# Altium schematic sheet MCU.SchDoc(MCU)
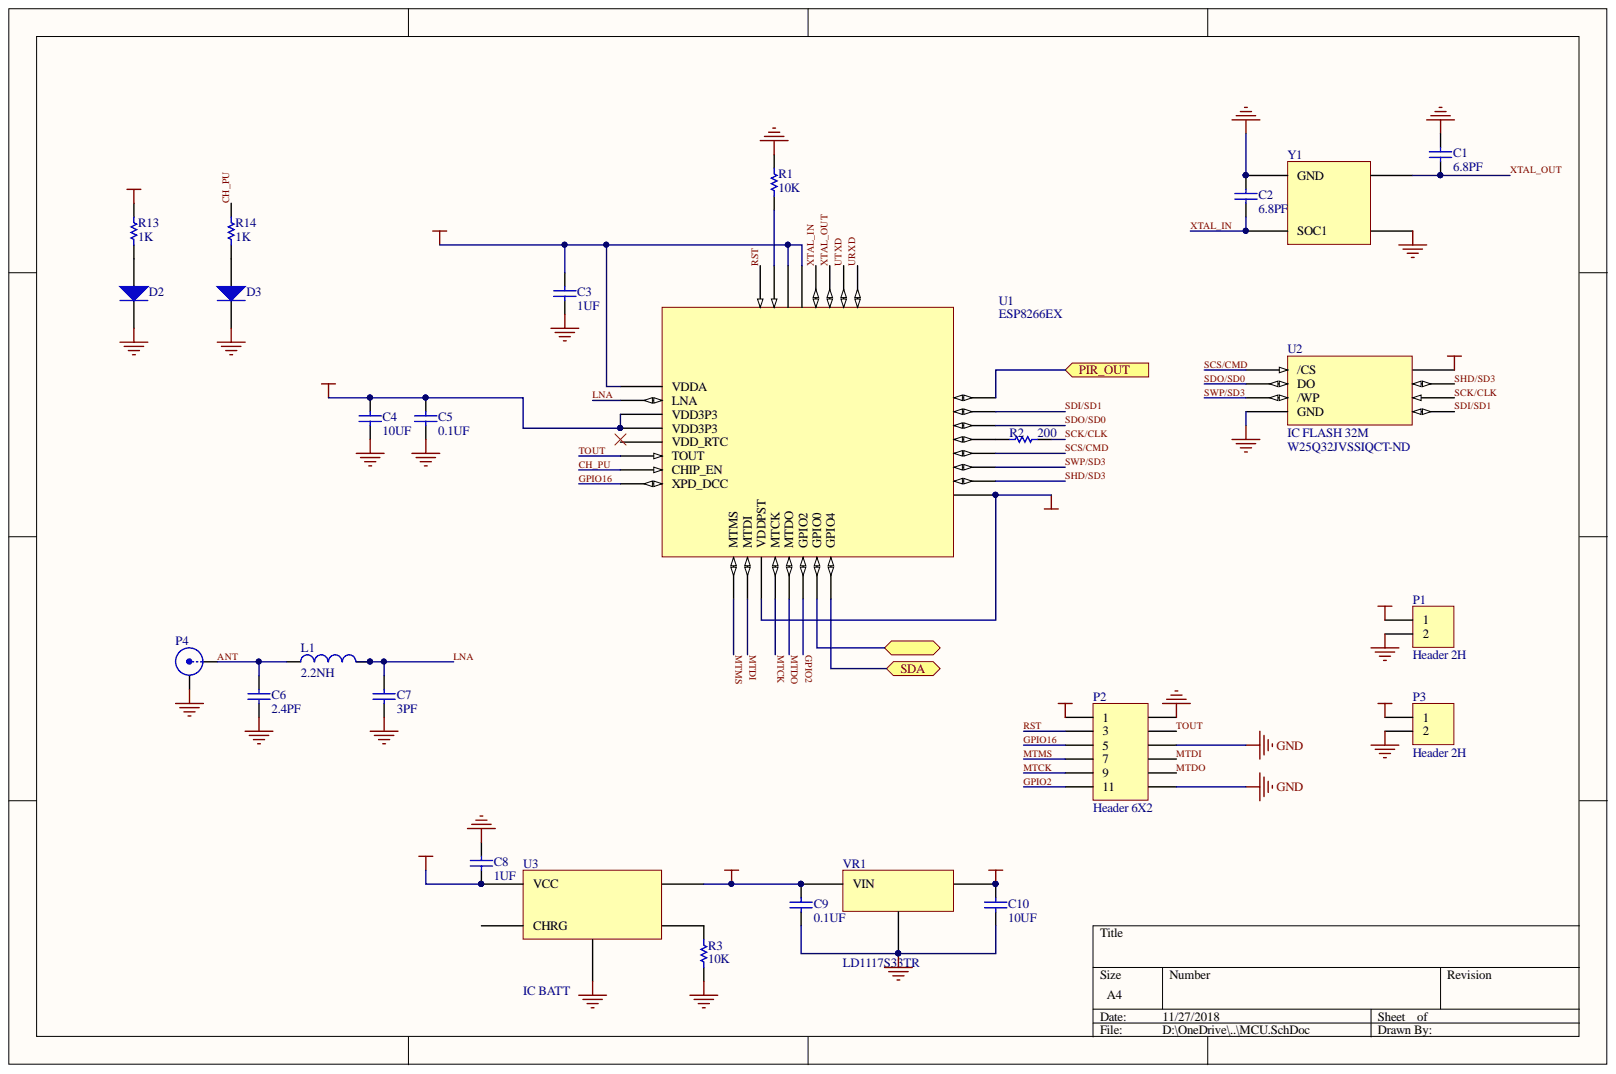
[diagram p0: MCU.SchDoc]

## Parts
C1  6.8PF  [Chip2PinSM127P600-8N-SAMSUNG-0603]
C2  6.8PF  [Chip2PinSM127P600-8N-SAMSUNG-0603]
C3  1UF  [Chip2PinSM127P600-8N-SAMSUNG-0603]
C4  10UF  [Chip2PinSM127P600-8N-SAMSUNG-0603]
C5  0.1UF  [Chip2PinSM127P600-8N-SAMSUNG-0603]
C6  2.4PF  [Chip2PinSM127P600-8N-SAMSUNG-0603]
C7  3PF  [Chip2PinSM127P600-8N-TDK_0603]
C8  1UF  [Chip2PinSM127P600-8N-SAMSUNG-0603]
C9  0.1UF  [Chip2PinSM127P600-8N-SAMSUNG-0603]
C10  10UF  [Chip2PinSM127P600-8N-SAMSUNG-0603]
D2  SML-D12M8WT86  [LED_0603_ROHM]  (value not drawn)
D3  SML-D12M8WT86  [LED_0603_ROHM]  (value not drawn)
L1  2.2NH  [Chip2PinSM127P600-8N-SAMSUNG-0603]
P1  Header 2H  [PCBComponent_1 - duplicate]
P2  Header 6X2  [HDR2X6]
P3  Header 2H  [PCBComponent_1 - duplicate]
P4  (no value)  [PCBComponent_1 - duplicate3]
R1  10K  [Chip2PinSM127P600-8N-vishay-0603]
R2  200  [Chip2PinSM127P600-8N-SAMSUNG-0603]
R3  10K  [Chip2PinSM127P600-8N-vishay-0603]
R13  1K  [Chip2PinSM127P600-8N-vishay-0603]
R14  1K  [Chip2PinSM127P600-8N-vishay-0603]
U1  ESP8266EX  [QFN127P600-8N]
U2  IC FLASH 32M  Supplier Part Number 1: W25Q32JVSSIQCT-ND  Memory Format: FLASH  [SOIC127P600-8N-208]
U3  IC BATT  [SOT23127P600-8N-STC4054]
VR1  LD1117S33TR  [SOT230P700X180-4N_ST]
Y1  FA-128 26.0000MF10Z-W5  [PCBComponent_1]  (value not drawn)

## Ports
PIR_OUT, SCL, SDA

## Nets
3v3: C3.1 C4.1 C5.2 C10.1 P2.1 R13.2 U1.1(VDDA) U1.3(VDD3P3) U1.4(VDD3P3) U1.11(VDDPST) U1.17 U1.29 U1.30 U2.8 VR1.2
GND: C1.2 C2.2 C3.2 C4.2 C5.1 C6.2 C7.2 C8.2 C9.2 C10.2 D2.2 D3.2 P1.2 P2.2 P2.6 P2.12 P3.2 P4.2 R1.2 R3.2 U2.4(GND) U3.2 VR1.1 Y1.2 Y1.4(GND)
VBAT: C9.1 P1.1 U3.3 VR1.3
VIN: C8.1 P3.1 U3.4(VCC)
ANT: C6.1 L1.1 P4.1
CH_PU: R14.2 U1.7(CHIP_EN)
GPIO2: P2.11 U1.14(GPIO2)
GPIO16: P2.5 U1.8(XPD_DCC)
LNA: C7.1 L1.2 U1.2(LNA)
MTCK: P2.9 U1.12(MTCK)
MTDI: P2.8 U1.10(MTDI)
MTDO: P2.10 U1.13(MTDO)
MTMS: P2.7 U1.9(MTMS)
PIR_OUT: U1.24 ; port PIR_OUT
RST: P2.3 U1.32
SCK/CLK: R2.2 U2.6
SCL: U1.15(GPIO0) ; port SCL
SCS/CMD: U1.20 U2.1(/CS)
SDA: U1.16(GPIO4) ; port SDA
SDI/SD1: U1.23 U2.5
SDO/SD0: U1.22 U2.2(DO)
SHD/SD3: U1.18 U2.7
SWP/SD3: U1.19 U2.3(/WP)
TOUT: P2.4 U1.6(TOUT)
URXD: U1.25
UTXD: U1.26
XTAL_IN: C2.1 U1.28 Y1.1(SOC1)
XTAL_OUT: C1.1 U1.27 Y1.3
NetD2_1: D2.1 R13.1
NetD3_1: D3.1 R14.1
NetR1_1: R1.1 U1.31
NetR2_1: R2.1 U1.21
NetR3_1: R3.1 U3.5

Not connected: U1 5(VDD_RTC); U3 1(CHRG)

## Library data of the parts
C1 C2 = CL10C6R8DB8NNNC: CAP CER 6.8PF 50V C0G/NP0 0603; Manufacturer: Samsung Electro-Mechanics; Tolerance: ±0.5pF; Temperature Coefficient: C0G, NP0; Notification: Current market demand for these product types have resulted in fluctuating and extending lead times. Lead times may differ.; Voltage - Rated: 50V; Thickness (Max): 0.035" (0.90mm); Supplier Part Number 1: 1276-2334-1-ND; Supplier 1: Digi-Key; Stock 1: 40000; Size / Dimension: 0.063" L x 0.031" W (1.60mm x 0.80mm); RoHS: RoHS Compliant; Ratings: -; Pricing 1: 1=0.08, 10=0.039, 100=0.0174, 500=0.01244, 1000=0.00978 (EUR); Part Status: Active; Packaging: Cut Tape (CT); Package / Case: 0603 (1608 Metric); Operating Temperature: -55°C ~ 125°C; Mounting Type: Surface Mount, MLCC; Manufacturer Part Number: CL10C6R8DB8NNNC; Library Name: Schlib1.SchLib; Lead Style: -; Lead Spacing: -; Height - Seated (Max): -; Features: -; ComponentLink1URL: http://www.samsungsem.com/kr/support/product-search/mlcc/CL10C6R8DB8NNNC.jsp; ComponentLink1Description: http://www.samsungsem.com/kr/support/product-search/mlcc/CL10C6R8DB8NNNC.jsp; Component Kind: Standard; Category: Capacitors; Applications: General Purpose
C3 C8 = CL10B105KP8NNNC: CAP CER 1UF 10V X7R 0603; Manufacturer: Samsung Electro-Mechanics; Tolerance: ±10%; Notification: Current market demand for these product types have resulted in fluctuating and extending lead times. Lead times may differ.; Voltage - Rated: 10V; Thickness (Max): 0.035" (0.90mm); Temperature Coefficient: X7R; Supplier Part Number 1: 1276-1946-1-ND; Supplier 1: Digi-Key; Stock 1: 40000; Size / Dimension: 0.063" L x 0.031" W (1.60mm x 0.80mm); RoHS: RoHS Compliant; Ratings: -; Pricing 1: 1=0.08, 10=0.044, 100=0.0197, 500=0.01408, 1000=0.01106 (EUR); Part Status: Active; Packaging: Cut Tape (CT); Package / Case: 0603 (1608 Metric); Operating Temperature: -55°C ~ 125°C; Mounting Type: Surface Mount, MLCC; Manufacturer Part Number: CL10B105KP8NNNC; Library Name: Schlib1.SchLib; Lead Style: -; Lead Spacing: -; Height - Seated (Max): -; Features: -; ComponentLink1URL: http://www.samsungsem.com/kr/support/product-search/mlcc/CL10B105KP8NNNC.jsp; ComponentLink1Description: http://www.samsungsem.com/kr/support/product-search/mlcc/CL10B105KP8NNNC.jsp; Component Kind: Standard; Category: Capacitors; Applications: General Purpose
C4 C10 = CL10A106KQ8NNNC: CAP CER 10UF 6.3V X5R 0603; Manufacturer: Samsung Electro-Mechanics; Tolerance: ±10%; Notification: Current market demand for these product types have resulted in fluctuating and extending lead times. Lead times may differ.; Voltage - Rated: 6.3V; Thickness (Max): 0.035" (0.90mm); Temperature Coefficient: X5R; Supplier Part Number 1: 1276-1038-1-ND; Supplier 1: Digi-Key; Stock 1: 40000; Size / Dimension: 0.063" L x 0.031" W (1.60mm x 0.80mm); RoHS: RoHS Compliant; Ratings: -; Pricing 1: 1=0.25, 10=0.177, 100=0.1001, 500=0.07064, 1000=0.0618 (EUR); Part Status: Active; Packaging: Cut Tape (CT); Package / Case: 0603 (1608 Metric); Operating Temperature: -55°C ~ 85°C; Mounting Type: Surface Mount, MLCC; Manufacturer Part Number: CL10A106KQ8NNNC; Library Name: Schlib1.SchLib; Lead Style: -; Lead Spacing: -; Height - Seated (Max): -; Features: -; ComponentLink1URL: http://www.samsungsem.com/kr/support/product-search/mlcc/CL10A106KQ8NNNC.jsp; ComponentLink1Description: http://www.samsungsem.com/kr/support/product-search/mlcc/CL10A106KQ8NNNC.jsp; Component Kind: Standard; Category: Capacitors; Applications: General Purpose
C5 C9 = CL10F104ZO8NNNC: CAP CER 0.1UF 16V Y5V 0603; Manufacturer: Samsung Electro-Mechanics; Tolerance: -20%, +80%; Temperature Coefficient: Y5V (F); Notification: Current market demand for these product types have resulted in fluctuating and extending lead times. Lead times may differ.; Voltage - Rated: 16V; Thickness (Max): 0.035" (0.90mm); Supplier Part Number 1: 1276-1258-1-ND; Supplier 1: Digi-Key; Stock 1: 40000; Size / Dimension: 0.063" L x 0.031" W (1.60mm x 0.80mm); RoHS: RoHS Compliant; Ratings: -; Pricing 1: 1=0.08, 10=0.032, 100=0.0144, 500=0.01026, 1000=0.00806 (EUR); Part Status: Active; Packaging: Cut Tape (CT); Package / Case: 0603 (1608 Metric); Operating Temperature: -30°C ~ 85°C; Mounting Type: Surface Mount, MLCC; Manufacturer Part Number: CL10F104ZO8NNNC; Library Name: Schlib1.SchLib; Lead Style: -; Lead Spacing: -; Height - Seated (Max): -; Features: -; ComponentLink1URL: http://www.samsungsem.com/kr/support/product-search/mlcc/CL10F104ZO8NNNC.jsp; ComponentLink1Description: http://www.samsungsem.com/kr/support/product-search/mlcc/CL10F104ZO8NNNC.jsp; Component Kind: Standard; Category: Capacitors; Applications: General Purpose
C6 = CL10C2R4BB8NNNC: CAP CER 2.4PF 50V C0G/NP0 0603; Manufacturer: Samsung Electro-Mechanics; Tolerance: ±0.1pF; Temperature Coefficient: C0G, NP0; Notification: Current market demand for these product types have resulted in fluctuating and extending lead times. Lead times may differ.; Voltage - Rated: 50V; Thickness (Max): 0.035" (0.90mm); Supplier Part Number 1: 1276-2254-1-ND; Supplier 1: Digi-Key; Stock 1: 40000; Size / Dimension: 0.063" L x 0.031" W (1.60mm x 0.80mm); RoHS: RoHS Compliant; Ratings: -; Pricing 1: 1=0.08, 10=0.034, 100=0.0151, 500=0.01074, 1000=0.00844 (EUR); Part Status: Active; Packaging: Cut Tape (CT); Package / Case: 0603 (1608 Metric); Operating Temperature: -55°C ~ 125°C; Mounting Type: Surface Mount, MLCC; Manufacturer Part Number: CL10C2R4BB8NNNC; Library Name: Schlib1.SchLib; Lead Style: -; Lead Spacing: -; Height - Seated (Max): -; Features: -; ComponentLink1URL: http://www.samsungsem.com/kr/support/product-search/mlcc/CL10C2R4BB8NNNC.jsp; ComponentLink1Description: http://www.samsungsem.com/kr/support/product-search/mlcc/CL10C2R4BB8NNNC.jsp; Component Kind: Standard; Category: Capacitors; Applications: General Purpose
C7 = CL10C030BB8NNNC: CAP CER 3PF 50V C0G/NP0 0603; Manufacturer: Samsung Electro-Mechanics; Tolerance: ±0.1pF; Temperature Coefficient: C0G, NP0; Notification: Current market demand for these product types have resulted in fluctuating and extending lead times. Lead times may differ.; Voltage - Rated: 50V; Thickness (Max): 0.035" (0.90mm); Supplier Part Number 1: 1276-2125-1-ND; Supplier 1: Digi-Key; Stock 1: 40000; Size / Dimension: 0.063" L x 0.031" W (1.60mm x 0.80mm); RoHS: RoHS Compliant; Ratings: -; Pricing 1: 1=0.08, 10=0.026, 100=0.0116, 500=0.00826, 1000=0.00649 (EUR); Part Status: Active; Packaging: Cut Tape (CT); Package / Case: 0603 (1608 Metric); Operating Temperature: -55°C ~ 125°C; Mounting Type: Surface Mount, MLCC; Manufacturer Part Number: CL10C030BB8NNNC; Library Name: Schlib1.SchLib; Lead Style: -; Lead Spacing: -; Height - Seated (Max): -; Features: -; ComponentLink1URL: http://www.samsungsem.com/kr/support/product-search/mlcc/CL10C030BB8NNNC.jsp; ComponentLink1Description: http://www.samsungsem.com/kr/support/product-search/mlcc/CL10C030BB8NNNC.jsp; Component Kind: Standard; Category: Capacitors; Applications: General Purpose
D2 D3 = SML-D12M8WT86: LED GREEN DIFFUSED 0603 SMD; Manufacturer: Rohm Semiconductor; Wavelength - Dominant: 572nm; Voltage - Forward (Vf) (Typ): 2.2V; Millicandela Rating: 25mcd; Lens Style: Rectangle with Flat Top; Lens Size: 1.20mm x 0.80mm; Lens Color: White; Current - Test: 20mA; Configuration: Standard; Wavelength - Peak: -; Viewing Angle: -; Supplier Device Package: 0603 (1608 Metric); Supplier 1: Digi-Key; Stock 1: 315568; Size / Dimension: 1.60mm L x 0.80mm W; RoHS: RoHS Compliant; Pricing 1: 1=0.3, 10=0.219, 25=0.1616, 100=0.1154, 250=0.07848, 500=0.06926, 1000=0.05425 (EUR); Part Status: Active; Packaging: Cut Tape (CT); Package / Case: 0603 (1608 Metric); Mounting Type: Surface Mount; Manufacturer Part Number: SML-D12M8WT86; Library Name: Schlib1.SchLib; Lens Transparency: Diffused; Height (Max): 0.65mm; Features: -; ComponentLink1URL: https://www.rohm.com/datasheet/SML-D12V8W; ComponentLink1Description: https://www.rohm.com/datasheet/SML-D12V8W; Component Kind: Standard; Color: Green; Category: Optoelectronics; Base Part Number: SML-D12
L1 = L-14C2N2SV4T: FIXED IND 2.2NH 300MA 150 MOHM; Manufacturer: Johanson Technology Inc.; Type: Multilayer; Tolerance: ±0.3nH; Shielding: Unshielded; Q @ Freq: 8 @ 100MHz; Material - Core: Ceramic; Frequency - Self Resonant: 12GHz; DC Resistance (DCR): 150 mOhm Max; Supplier Part Number 1: 712-1427-1-ND; Supplier Device Package: 0603 (1608 Metric); Supplier 1: Digi-Key; Stock 1: 17153; Size / Dimension: 0.063" L x 0.031" W (1.60mm x 0.80mm); RoHS: RoHS Compliant; Ratings: -; Pricing 1: 1=0.08, 10=0.052, 100=0.0348, 500=0.02898, 1000=0.02434 (EUR); Part Status: Active; Packaging: Cut Tape (CT); Package / Case: 0603 (1608 Metric); Operating Temperature: -40°C ~ 100°C; Mounting Type: Surface Mount; Manufacturer Part Number: L-14C2N2SV4T; Library Name: Schlib1.SchLib; Height - Seated (Max): 0.037" (0.95mm); Current - Saturation: -; ComponentLink1URL: https://media.digikey.com/pdf/Data%20Sheets/Johanson%20Technology/RF_Ceramic_Chip_Inductors.pdf; ComponentLink1Description: https://media.digikey.com/pdf/Data%20Sheets/Johanson%20Technology/RF_Ceramic_Chip_Inductors.pdf; Component Kind: Standard; Category: Inductors, Coils, Chokes
P1 P3 = Header 2H: Header, 2-Pin, Right Angle; Library Name: Miscellaneous Connectors.IntLib; LatestRevisionNote: Re-released for DXP Platform.; LatestRevisionDate: 17-Jul-2002; Component Kind: Standard; Publisher: Altium Limited
P2 = Header 6X2: Header, 6-Pin, Dual row; Signal Integrity: Connector; Library Name: Miscellaneous Connectors.IntLib; LatestRevisionNote: Re-released for DXP Platform.; LatestRevisionDate: 17-Jul-2002; Component Kind: Standard; Publisher: Altium Limited
P4 = SMB: SMB Straight Connector; Library Name: Miscellaneous Connectors.IntLib; LatestRevisionNote: Re-released for DXP Platform.; LatestRevisionDate: 17-Jul-2002; Component Kind: Standard; Publisher: Altium Limited
R1 R3 = MCT06030C1002FP500: RES SMD 10K OHM 1% 1/8W 0603; Manufacturer: Vishay Beyschlag; Temperature Coefficient: ±50ppm/°C; Power (Watts): 0.125W, 1/8W; Tolerance: ±1%; Supplier Part Number 1: MCT0603-10.0K-CFCT-ND; Supplier Device Package: 0603; Supplier 1: Digi-Key; Stock 1: 1201929; Size / Dimension: 0.061" L x 0.033" W (1.55mm x 0.85mm); RoHS: RoHS Compliant; Pricing 1: 1=0.16, 10=0.133, 100=0.0521, 1000=0.02182, 2500=0.02 (EUR); Part Status: Active; Packaging: Cut Tape (CT); Package / Case: 0603 (1608 Metric); Operating Temperature: -55°C ~ 155°C; Manufacturer Part Number: MCT06030C1002FP500; Library Name: Schlib1.SchLib; Height - Seated (Max): 0.022" (0.55mm); Features: Anti-Sulfur; Failure Rate: -; Composition: Thin Film; ComponentLink1URL: http://www.vishay.com/docs/28705/mcx0x0xpro.pdf; ComponentLink1Description: http://www.vishay.com/docs/28705/mcx0x0xpro.pdf; Component Kind: Standard; Category: Resistors
R2 = RC1608F201CS: RES SMD 200 OHM 1% 1/10W 0603; Manufacturer: Samsung Electro-Mechanics; Temperature Coefficient: ±100ppm/°C; Power (Watts): 0.1W, 1/10W; Tolerance: ±1%; Supplier Part Number 1: 1276-4584-1-ND; Supplier Device Package: 0603; Supplier 1: Digi-Key; Stock 1: 235074; Size / Dimension: 0.063" L x 0.032" W (1.60mm x 0.80mm); RoHS: RoHS Compliant; Pricing 1: 1=0.08, 10=0.028, 100=0.0115, 1000=0.00516, 2500=0.00448 (EUR); Part Status: Active; Packaging: Cut Tape (CT); Package / Case: 0603 (1608 Metric); Operating Temperature: -55°C ~ 155°C; Manufacturer Part Number: RC1608F201CS; Library Name: Schlib1.SchLib; Height - Seated (Max): 0.022" (0.55mm); Features: Moisture Resistant; Failure Rate: -; Composition: Thick Film; ComponentLink1URL: http://www.samsungsem.com/kr/support/product-search/chip-resistor/RC1608F201CS.jsp; ComponentLink1Description: http://www.samsungsem.com/kr/support/product-search/chip-resistor/RC1608F201CS.jsp; Component Kind: Standard; Category: Resistors
R13 R14 = MCT06030C1001FP500: RES SMD 1K OHM 1% 1/8W 0603; Manufacturer: Vishay Beyschlag; Temperature Coefficient: ±50ppm/°C; Power (Watts): 0.125W, 1/8W; Tolerance: ±1%; Supplier Part Number 1: MCT0603-1.00K-CFCT-ND; Supplier Device Package: 0603; Supplier 1: Digi-Key; Stock 1: 193422; Size / Dimension: 0.061" L x 0.033" W (1.55mm x 0.85mm); RoHS: RoHS Compliant; Pricing 1: 1=0.16, 10=0.133, 100=0.0521, 1000=0.02182, 2500=0.02 (EUR); Part Status: Active; Packaging: Cut Tape (CT); Package / Case: 0603 (1608 Metric); Operating Temperature: -55°C ~ 155°C; Manufacturer Part Number: MCT06030C1001FP500; Library Name: Schlib1.SchLib; Height - Seated (Max): 0.022" (0.55mm); Features: Anti-Sulfur; Failure Rate: -; Composition: Thin Film; ComponentLink1URL: http://www.vishay.com/docs/28705/mcx0x0xpro.pdf; ComponentLink1Description: http://www.vishay.com/docs/28705/mcx0x0xpro.pdf; Component Kind: Standard; Category: Resistors
U1 = ESP8266EX: SMD IC ESP8266EX, QFN32-PIN, 5_5; Manufacturer: Espressif Systems; Voltage - Supply: 2.5 V ~ 3.6 V; Serial Interfaces: I²C, I²S, SPI, UART; Sensitivity: -91dBm; RF Family/Standard: WiFi; Protocol: 802.11b/g/n; Power - Output: 20dBm; Frequency: 2.4GHz; Data Rate (Max): 54Mbps; Current - Transmitting: 120mA ~ 170mA; Current - Receiving: 50mA ~ 56mA; Type: TxRx + MCU; Supplier Part Number 1: 1904-1001-1-ND; Supplier 1: Digi-Key; Stock 1: 2122; RoHS: RoHS Compliant; Pricing 1: 1=2.49, 10=2.222, 25=2, 100=1.8223, 250=1.64452, 500=1.47562 (EUR); Part Status: Active; Packaging: Cut Tape (CT); Package / Case: 32-VFQFN Exposed Pad; Modulation: -; Memory Size: -; Manufacturer Part Number: ESP8266EX; Library Name: Schlib1.SchLib; ComponentLink1URL: https://espressif.com/sites/default/files/documentation/0a-esp8266ex_datasheet_en.pdf; ComponentLink1Description: https://espressif.com/sites/default/files/documentation/0a-esp8266ex_datasheet_en.pdf; Component Kind: Standard; Category: RF/IF and RFID
U2 = W25Q32JVSSIQ TR: IC FLASH 32M SPI 133MHZ 8SOIC; Manufacturer: Winbond Electronics; Write Cycle Time - Word, Page: 3ms; Voltage - Supply: 2.7 V ~ 3.6 V; Memory Type: Non-Volatile; Memory Size: 32Mb (4M x 8); Memory Interface: SPI - Quad I/O; Technology: FLASH - NOR; Supplier Device Package: 8-SOIC; Supplier 1: Digi-Key; Stock 1: 102739; RoHS: RoHS Compliant; Pricing 1: 1=0.83, 10=0.753, 25=0.7428, 50=0.739, 100=0.6606, 250=0.65488, 500=0.64536, 750=0.63545, 1000=0.62208 (EUR); Part Status: Active; Packaging: Cut Tape (CT); Package / Case: 8-SOIC (0.209", 5.30mm Width); Operating Temperature: -40°C ~ 85°C (TA); Mounting Type: Surface Mount; Manufacturer Part Number: W25Q32JVSSIQ TR; Library Name: Schlib1.SchLib; ComponentLink1URL: http://www.winbond.com/resource-files/w25q32jv%20revg%2003272018%20plus.pdf; ComponentLink1Description: http://www.winbond.com/resource-files/w25q32jv%20revg%2003272018%20plus.pdf; Component Kind: Standard; Category: Integrated Circuits (ICs)
U3 = STC4054GR: IC BATT CHRGR 800MA TSOT23-5; Manufacturer: STMicroelectronics; Voltage - Supply (Max): 6.5V; Programmable Features: Current; Number of Cells: 1; Current - Charging: Constant - Programmable; Battery Pack Voltage: 4.2V; Battery Chemistry: Lithium-Ion; Supplier Part Number 1: 497-5809-6-ND; Supplier Device Package: TSOT-23-5; Supplier 1: Digi-Key; Stock 1: 11621; RoHS: RoHS Compliant; Pricing 1: 1=1.35, 10=1.208, 100=0.9707, 500=0.7975, 1000=0.66079 (EUR); Part Status: Active; Packaging: Digi-Reel®; Package / Case: SOT-23-5 Thin, TSOT-23-5; Operating Temperature: -40°C ~ 85°C (TA); Manufacturer Part Number: STC4054GR; Library Name: Schlib1.SchLib; Interface: -; Fault Protection: -; ComponentLink1URL: http://www.st.com/content/ccc/resource/technical/document/datasheet/df/b9/e6/5b/ec/92/45/ed/CD00132951.pdf/files/CD00132951.pdf/jcr:content/translations/en.CD00132951.pdf; ComponentLink1Description: http://www.st.com/content/ccc/resource/technical/document/datasheet/df/b9/e6/5b/ec/92/45/ed/CD00132951.pdf/files/CD00132951.pdf/jcr:content/translations/en.CD00132951.pdf; Component Kind: Standard; Charge Current - Max: 800mA; Category: Integrated Circuits (ICs); Base Part Number: STC4054
VR1 = LD1117S33TR: IC REG LINEAR 3.3V 800MA SOT223; Manufacturer: STMicroelectronics; Voltage Dropout (Max): 1.2V @ 800mA; Voltage - Input (Max): 15V; PSRR: 75dB (120Hz); Protection Features: Over Current, Over Temperature; Output Type: Fixed; Output Configuration: Positive; Voltage - Output (Min/Fixed): 3.3V; Voltage - Output (Max): -; Supplier Part Number 1: 497-1242-1-ND; Supplier Device Package: SOT-223; Supplier 1: Digi-Key; Stock 1: 10; RoHS: RoHS Compliant; Pricing 1: 1=0.37, 10=0.316, 100=0.2358, 500=0.18526, 1000=0.14317 (EUR); Part Status: Active; Packaging: Cut Tape (CT); Package / Case: TO-261-4, TO-261AA; Operating Temperature: 0°C ~ 125°C; Mounting Type: Surface Mount; Manufacturer Part Number: LD1117S33TR; Library Name: Schlib1.SchLib; Current - Output: 800mA; Control Features: -; ComponentLink1URL: http://www.st.com/content/ccc/resource/technical/document/datasheet/99/3b/7d/91/91/51/4b/be/CD00000544.pdf/files/CD00000544.pdf/jcr:content/translations/en.CD00000544.pdf; ComponentLink1Description: http://www.st.com/content/ccc/resource/technical/document/datasheet/99/3b/7d/91/91/51/4b/be/CD00000544.pdf/files/CD00000544.pdf/jcr:content/translations/en.CD00000544.pdf; Component Kind: Standard; Category: Integrated Circuits (ICs); Base Part Number: LD1117
Y1 = FA-128 26.0000MF10Z-W5: CRYSTAL 26.0000MHZ 12PF SMD; Manufacturer: EPSON; Operating Mode: Fundamental; Frequency Tolerance: ±10ppm; Type: MHz Crystal; Supplier Part Number 1: SER4186CT-ND; Supplier 1: Digi-Key; Stock 1: 200409; Size / Dimension: 0.079" L x 0.063" W (2.00mm x 1.60mm); RoHS: RoHS Compliant; Ratings: -; Pricing 1: 1=0.5, 10=0.419, 50=0.3772, 100=0.3353, 500=0.3185, 1000=0.2682 (EUR); Part Status: Active; Packaging: Cut Tape (CT); Package / Case: 4-SMD, No Lead; Operating Temperature: -20°C ~ 75°C; Mounting Type: Surface Mount; Manufacturer Part Number: FA-128 26.0000MF10Z-W5; Load Capacitance: 12pF; Library Name: Schlib1.SchLib; Height - Seated (Max): 0.020" (0.50mm); Frequency Stability: ±10ppm; ComponentLink1URL: https://support.epson.biz/td/api/doc_check.php?dl=brief_FA-128&lang=en; ComponentLink1Description: https://support.epson.biz/td/api/doc_check.php?dl=brief_FA-128&lang=en; Component Kind: Standard; Category: Crystals, Oscillators, Resonators
